# Altium schematic sheet smd_challenge_extreme.SchDoc
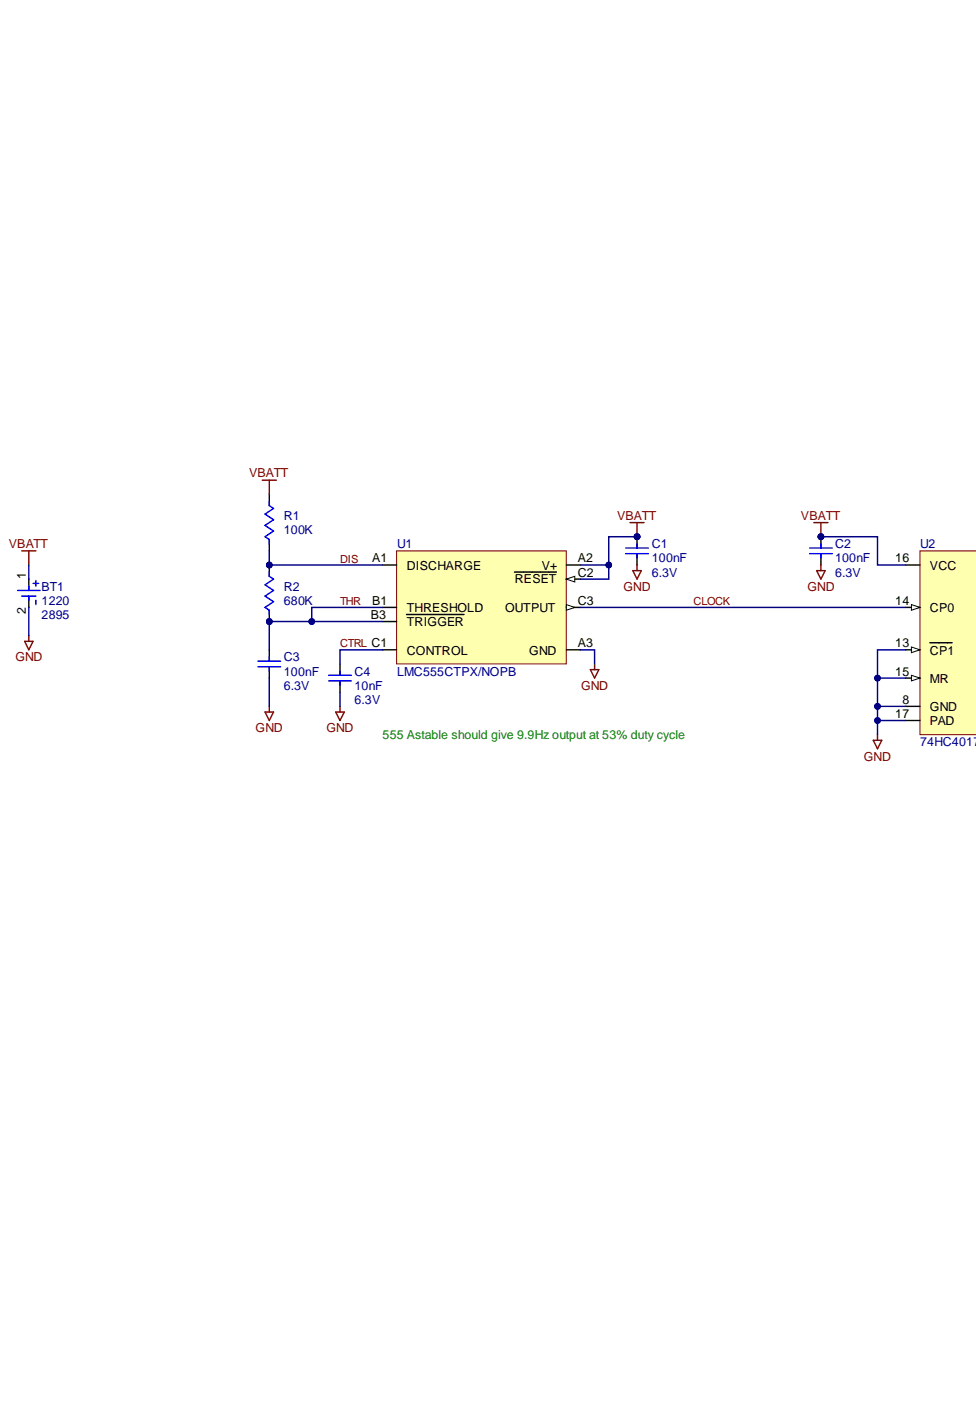
[diagram p0: smd_challenge_extreme.SchDoc, left]
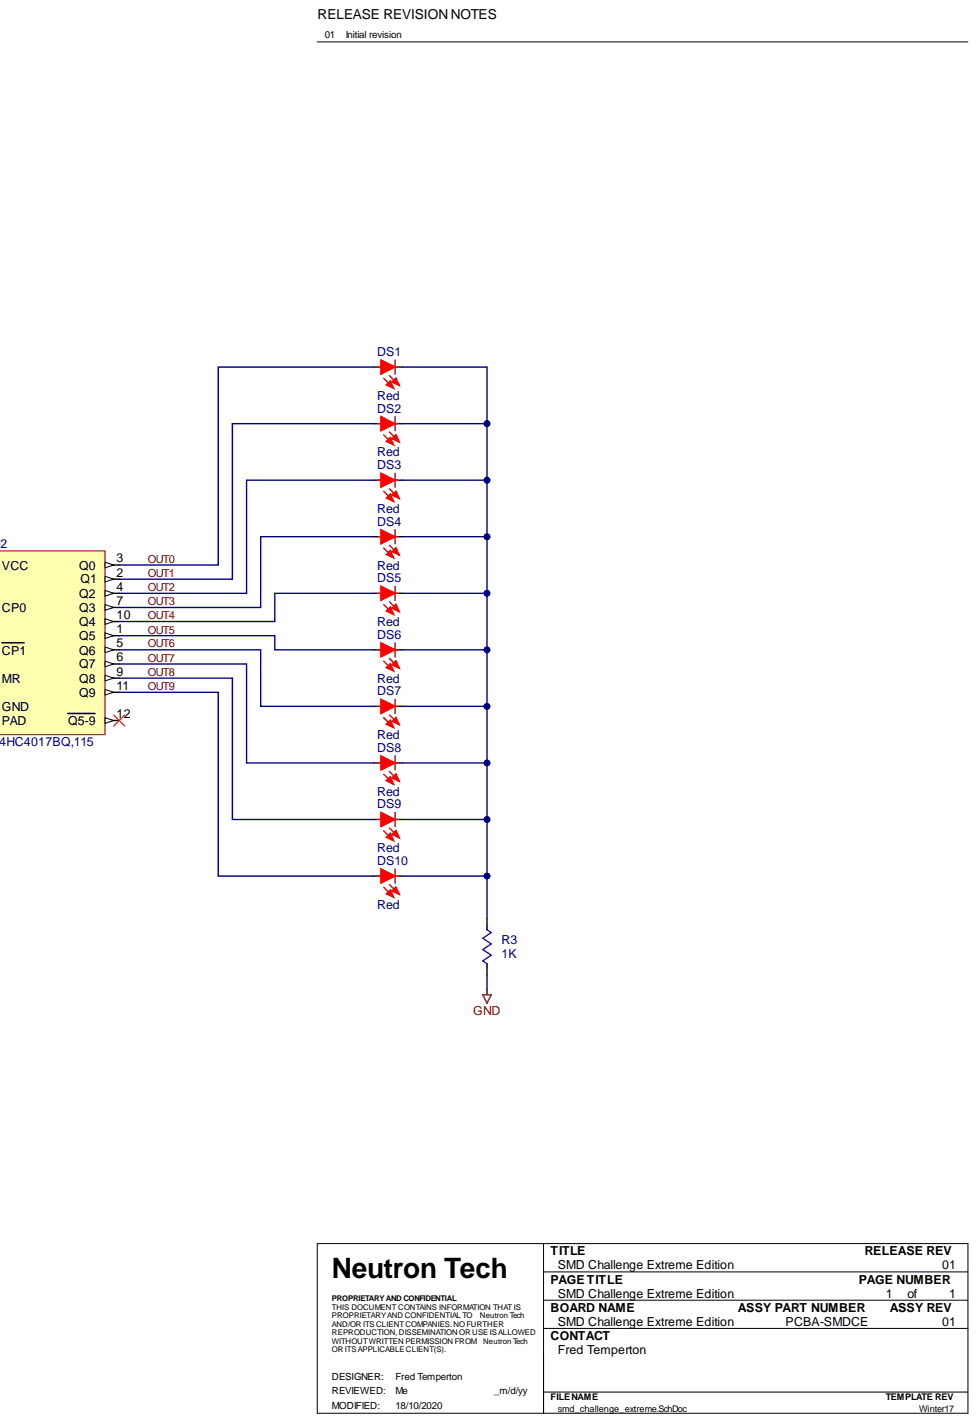
[diagram p1: smd_challenge_extreme.SchDoc, right]

## Parts
BT1  Manufacturer Part Number 1: 2895  Size: 1220  [BATT1220-KEYSTONE2895]  (hidden comment: ?)
C1  Capacitance 1: 100nF  Voltage 1: 6.3V  [CAPC0402X02N]  (hidden comment: ?)
C2  Capacitance 1: 100nF  Voltage 1: 6.3V  [CAPC0402X02N]  (hidden comment: ?)
C3  Capacitance 1: 100nF  Voltage 1: 6.3V  [CAPC0402X02N]  (hidden comment: ?)
C4  Capacitance 1: 10nF  Votlage 1: 6.3V  [CAPC0402X02N]  (hidden comment: ?)
DS1  Colour: Red  [DIOC0604X02N]  (hidden comment: ?)
DS2  Colour: Red  [DIOC0604X02N]  (hidden comment: ?)
DS3  Colour: Red  [DIOC0604X02N]  (hidden comment: ?)
DS4  Colour: Red  [DIOC0604X02N]  (hidden comment: ?)
DS5  Colour: Red  [DIOC0604X02N]  (hidden comment: ?)
DS6  Colour: Red  [DIOC0604X02N]  (hidden comment: ?)
DS7  Colour: Red  [DIOC0604X02N]  (hidden comment: ?)
DS8  Colour: Red  [DIOC0604X02N]  (hidden comment: ?)
DS9  Colour: Red  [DIOC0604X02N]  (hidden comment: ?)
DS10  Colour: Red  [DIOC0604X02N]  (hidden comment: ?)
R1  Resistance 1: 100K  [RESC0402X01N]  (hidden comment: ?)
R2  Resistance 1: 680K  [RESC0402X01N]  (hidden comment: ?)
R3  Resistance 1: 1K  [RESC0402X01N]  (hidden comment: ?)
U1  Manufacturer Part Number 1: LMC555CTPX/NOPB  [BGA8C50P3X3_141X143X57]  (hidden comment: ?)
U2  Manufacturer Part Number 1: 74HC4017BQ,115  [QFN50P250X350X100_HS-17N]  (hidden comment: ?)

## Nets
GND: BT1.2 C1.2 C2.2 C3.2 C4.2 R3.2 U1.A3(GND) U2.8(GND) U2.13(CP1) U2.15(MR) U2.17(PAD)
VBATT: BT1.1 C1.1 C2.1 R1.1 U1.A2(V+) U1.C2(RESET) U2.16(VCC)
CLOCK: U1.C3(OUTPUT) U2.14(CP0)
CTRL: C4.1 U1.C1(CONTROL)
DIS: R1.2 R2.1 U1.A1(DISCHARGE)
OUT0: DS1.2 U2.3(Q0)
OUT1: DS2.2 U2.2(Q1)
OUT2: DS3.2 U2.4(Q2)
OUT3: DS4.2 U2.7(Q3)
OUT4: DS5.2 U2.10(Q4)
OUT5: DS6.2 U2.1(Q5)
OUT6: DS7.2 U2.5(Q6)
OUT7: DS8.2 U2.6(Q7)
OUT8: DS9.2 U2.9(Q8)
OUT9: DS10.2 U2.11(Q9)
THR: C3.1 R2.2 U1.B1(THRESHOLD) U1.B3(TRIGGER)
NetDS1_1: DS1.1 DS2.1 DS3.1 DS4.1 DS5.1 DS6.1 DS7.1 DS8.1 DS9.1 DS10.1 R3.1

Not connected: U2 12(Q5-9)

## Library data of the parts
BT1 = BAT CELL HOLDER 1220: CR1220 COIN CELL THM RETAINER; Manufacturer 1: Keystone Electronics; _Verified BOM: .mfr-pn?; _Verified Footprint: .mfr-pn? footprint?; Component Kind: Standard; Pricing 1: 1=0.62000, 25=0.52320, 100=0.44520, 250=0.41780, 500=0.37370, 2500=0.37210 (USD); Simulation: DC Source; Supplier 1: Digi-Key; Supplier Part Number 1: 36-2895-ND; _Verified Symbol: .mfr-pn?; Library Name: smd_challenge_extreme.SchLib
C1-C3 = CAP 100nF 01005: CAP CER 0.1UF 6.3V X5R 01005; Manufacturer 1: Kyocera AVX; Manufacturer Part Number 1: CM02X5R104M06AH; _Verified BOM: .mfr-pn?; _Verified Footprint: .mfr-pn? footprint?; Description-Param 1: Cap Ceramic 0.1uF 6.3V X5R 20% SMD 01005 85C T/R; Tolerance 1: 20%; Case/Package: Ceramic; Component Kind: Standard; ComponentLink1Description: http://global.kyocera.com/prdct/electro/pdf/capacitors/cm_e.pdf?src-supplier=Avnet+Asia; ComponentLink1URL: http://global.kyocera.com/prdct/electro/pdf/capacitors/cm_e.pdf?src-supplier=Avnet+Asia; Contact Plating: Tin; Length: 0.4mm; Max Operating Temperature: 85°C; Min Operating Temperature: -55°C; Mount: Surface Mount; Pricing 1: 1=0.10000, 10=0.05100, 50=0.02780, 100=0.02260, 500=0.01618, 1000=0.01271, 2500=0.01155, 5000=0.01063 (USD); Supplier 1: Digi-Key; Supplier Part Number 1: 1253-CM02X5R104M06AHCT-ND; _Verified Symbol: .mfr-pn?; Library Name: smd_challenge_extreme.SchLib
C4 = CAP 10nF 01005: CAP CER 10000PF 6.3V X7S 01005; Manufacturer 1: Taiyo Yuden; Manufacturer Part Number 1: JMK042C7103KC-W; _Verified BOM: .mfr-pn?; _Verified Footprint: .mfr-pn? footprint?; Component Kind: Standard; ComponentLink1Description: https://www.yuden.co.jp/productdata/catalog/mlcc02_e.pdf?src-supplier=Digi-Key; ComponentLink1URL: https://www.yuden.co.jp/productdata/catalog/mlcc02_e.pdf?src-supplier=Digi-Key; Description-Param 1: Cap Cer 10000PF 6.3V X7S 01005; Pricing 1: 1=0.10000, 10=0.01200, 50=0.00660, 100=0.00540, 500=0.00386, 1000=0.00303, 2500=0.00275, 5000=0.00253 (USD); Supplier 1: Digi-Key; Supplier Part Number 1: 587-6219-1-ND; _Verified Symbol: .mfr-pn?; Library Name: smd_challenge_extreme.SchLib
DS1-DS10 = LED RED 0201: LED RED CLEAR 2SMD; Manufacturer 1: Kingbright; Manufacturer Part Number 1: APG0603SEC-E-TT; _Verified BOM: .mfr-pn?; _Verified Footprint: .mfr-pn? footprint?; Dominant Wavelength: 624nm; Forward Voltage: 1.93V; Lens Color: Colorless; Test Current: 10mA; Case/Package: SMD/SMT; Component Kind: Standard; ComponentLink1Description: http://www.kingbrightusa.com/images/catalog/SPEC/APG0603SEC-E-TT.pdf?src-supplier=Digi-Key; ComponentLink1URL: http://www.kingbrightusa.com/images/catalog/SPEC/APG0603SEC-E-TT.pdf?src-supplier=Digi-Key; Description-Param 1: LED RED CLEAR 2SMD; Height 1: 0.25mm; Lead Free: Lead Free; Length: 0.65mm; Lens Transparency: Clear; Mount: Surface Mount; Pricing 1: 1=0.43000, 10=0.30600, 100=0.19970, 500=0.14490, 1000=0.11340 (USD); Supplier 1: Digi-Key; Supplier Part Number 1: 754-2028-1-ND; Width: 0.35mm; _Verified Symbol: .mfr-pn?; Library Name: smd_challenge_extreme.SchLib
R1 = RES 100K 01005: RES SMD 100K OHM 1% 1/32W 01005; Manufacturer 1: Cyntec; Manufacturer Part Number 1: PFR02S-104-FNH; _Verified BOM: .mfr-pn?; _Verified Footprint: .mfr-pn? footprint?; Component Kind: Standard; Pricing 1: 1=0.10000, 10=0.02600, 100=0.01060, 1000=0.00477, 2500=0.00414, 5000=0.00342 (USD); Supplier 1: Digi-Key; Supplier Part Number 1: 2037-PFR02S-104-FNHCT-ND; _Verified Symbol: .mfr-pn?; Library Name: smd_challenge_extreme.SchLib
R2 = RES 680K 01005: RES SMD 680K OHM 5% 1/32W 01005; Manufacturer 1: Panasonic; Manufacturer Part Number 1: ERJ-XGNJ684Y; _Verified BOM: .mfr-pn?; _Verified Footprint: .mfr-pn? footprint?; Max Power Dissipation: 30mW; Power Rating: 31mW; Temperature Coefficient 1: 200ppm/°C; Voltage Rating 1: 15V; Case Code (Imperial): 1005; Case Code (Metric): 0402; Case/Package: Molded; Component Kind: Standard; ComponentLink1Description: https://datasheet.ciiva.com/6553/erjxgn-6553846.pdf?src-supplier=Digi-Key; ComponentLink1URL: https://datasheet.ciiva.com/6553/erjxgn-6553846.pdf?src-supplier=Digi-Key; ComponentLink2Description: https://datasheet.ciiva.com/6553/erjxgn-6553848.pdf?src-supplier=Digi-Key; ComponentLink2URL: https://datasheet.ciiva.com/6553/erjxgn-6553848.pdf?src-supplier=Digi-Key; Description-Param 1: RES SMD 680K OHM 5% 1/32W 01005; Height 1: 0.006inch; Length: 0.016inch; Max Operating Temperature: 125°C; Min Operating Temperature: -55°C; Mount: Surface Mount; Pricing 1: 1=0.16000, 10=0.13200, 100=0.05160, 1000=0.02160, 2500=0.01980, 5000=0.01800 (USD); Resistor Type: Thick Film; Supplier 1: Digi-Key; Supplier Part Number 1: P680KAWCT-ND; Tolerance 1: 5%; Width: 0.008inch; _Verified Symbol: .mfr-pn?; Library Name: smd_challenge_extreme.SchLib
R3 = RES 1K 01005: RES SMD 1K OHM 1% 1/32W 01005; Manufacturer 1: Cyntec; Manufacturer Part Number 1: PFR02S-102-FNH; _Verified BOM: .mfr-pn?; _Verified Footprint: .mfr-pn? footprint?; Component Kind: Standard; Pricing 1: 1=0.10000, 10=0.02600, 100=0.01060, 1000=0.00477, 2500=0.00414, 5000=0.00342 (USD); Supplier 1: Digi-Key; Supplier Part Number 1: 2037-PFR02S-102-FNHCT-ND; _Verified Symbol: .mfr-pn?; Library Name: smd_challenge_extreme.SchLib
U1 = IC LMC555CTPX: IC OSC SINGLE TIMER 3MHZ 8-USMD; Manufacturer 1: TI National Semiconductor; _Verified BOM: .mfr-pn?; _Verified Footprint: .mfr-pn? footprint?; High Level Output Current: -10mA; Low Level Output Current: 50mA; Max Power Dissipation: 568mW; Max Supply Voltage: 15V; Min Supply Voltage: 1.5V; Nominal Supply Current: 50uA; Number of Timers/Counters: 1; Operating Supply Current: 150uA; Output Current: 100mA; Propagation Delay: 100ns; Component Kind: Standard; ComponentLink1Description: https://datasheet.ciiva.com/6666/lmc555-6666976.pdf?src-supplier=Digi-Key; ComponentLink1URL: https://datasheet.ciiva.com/6666/lmc555-6666976.pdf?src-supplier=Digi-Key; ComponentLink2Description: https://datasheet.ciiva.com/15152/getdatasheetpartid-628891-15152597.pdf?src-supplier=Verical; ComponentLink2URL: https://datasheet.ciiva.com/15152/getdatasheetpartid-628891-15152597.pdf?src-supplier=Verical; ComponentLink3Description: https://datasheet.ciiva.com/14274/lmc555-14274422.pdf?src-supplier=Avnet; ComponentLink3URL: https://datasheet.ciiva.com/14274/lmc555-14274422.pdf?src-supplier=Avnet; ComponentLink4Description: https://datasheet.ciiva.com/14274/lmc555-14274486.pdf?src-supplier=Avnet; ComponentLink4URL: https://datasheet.ciiva.com/14274/lmc555-14274486.pdf?src-supplier=Avnet; Description-Param 1: IC OSC SINGLE TIMER 3MHZ 8-USMD; Frequency 1: 3MHz; Lead Free: Lead Free; Max Operating Temperature: 125°C; Min Operating Temperature: -40°C; Mount: Surface Mount; Number of Pins: 8; Power Dissipation: 568mW; Pricing 1: 1=1.27000, 10=1.13300, 25=1.07520, 100=0.88320, 250=0.82560, 500=0.72960, 1000=0.57600 (USD); Quiescent Current: 50uA; Radiation Hardening: No; Supplier 1: Digi-Key; Supplier Part Number 1: 296-46430-1-ND; Turn-On Delay Time: 100ns; _Verified Symbol: .mfr-pn?; Library Name: smd_challenge_extreme.SchLib
U2 = IC 74HC4017BQ: IC JOHNSON DECADE CNTR 16-DHVQFN; Manufacturer 1: Nexperia; _Verified BOM: .mfr-pn?; _Verified Footprint: .mfr-pn? footprint?; Description-Param 1: 74HC4017; 74HCT4017 - Johnson decade counter with 10 decoded outputs; Component Kind: Standard; ComponentLink1Description: http://datasheet.octopart.com/74HC4017BQ%2C115-Nexperia-datasheet-86672705.pdf?src-supplier=Nexperia; ComponentLink1URL: http://datasheet.octopart.com/74HC4017BQ%2C115-Nexperia-datasheet-86672705.pdf?src-supplier=Nexperia; ComponentLink2Description: http://datasheet.octopart.com/74HC4017BQ%2C115-Philips-datasheet-547741.pdf?src-supplier=NXP+Semiconductors; ComponentLink2URL: http://datasheet.octopart.com/74HC4017BQ%2C115-Philips-datasheet-547741.pdf?src-supplier=NXP+Semiconductors; Pricing 1: 1=0.53000, 10=0.45000, 25=0.42000, 100=0.33600, 250=0.31200, 500=0.26400, 1000=0.20400 (USD); Supplier 1: Digi-Key; Supplier Part Number 1: 1727-7943-1-ND; _Verified Symbol: .mfr-pn?; Library Name: smd_challenge_extreme.SchLib
